# Altium schematic sheet pwm.SchDoc(pwm)
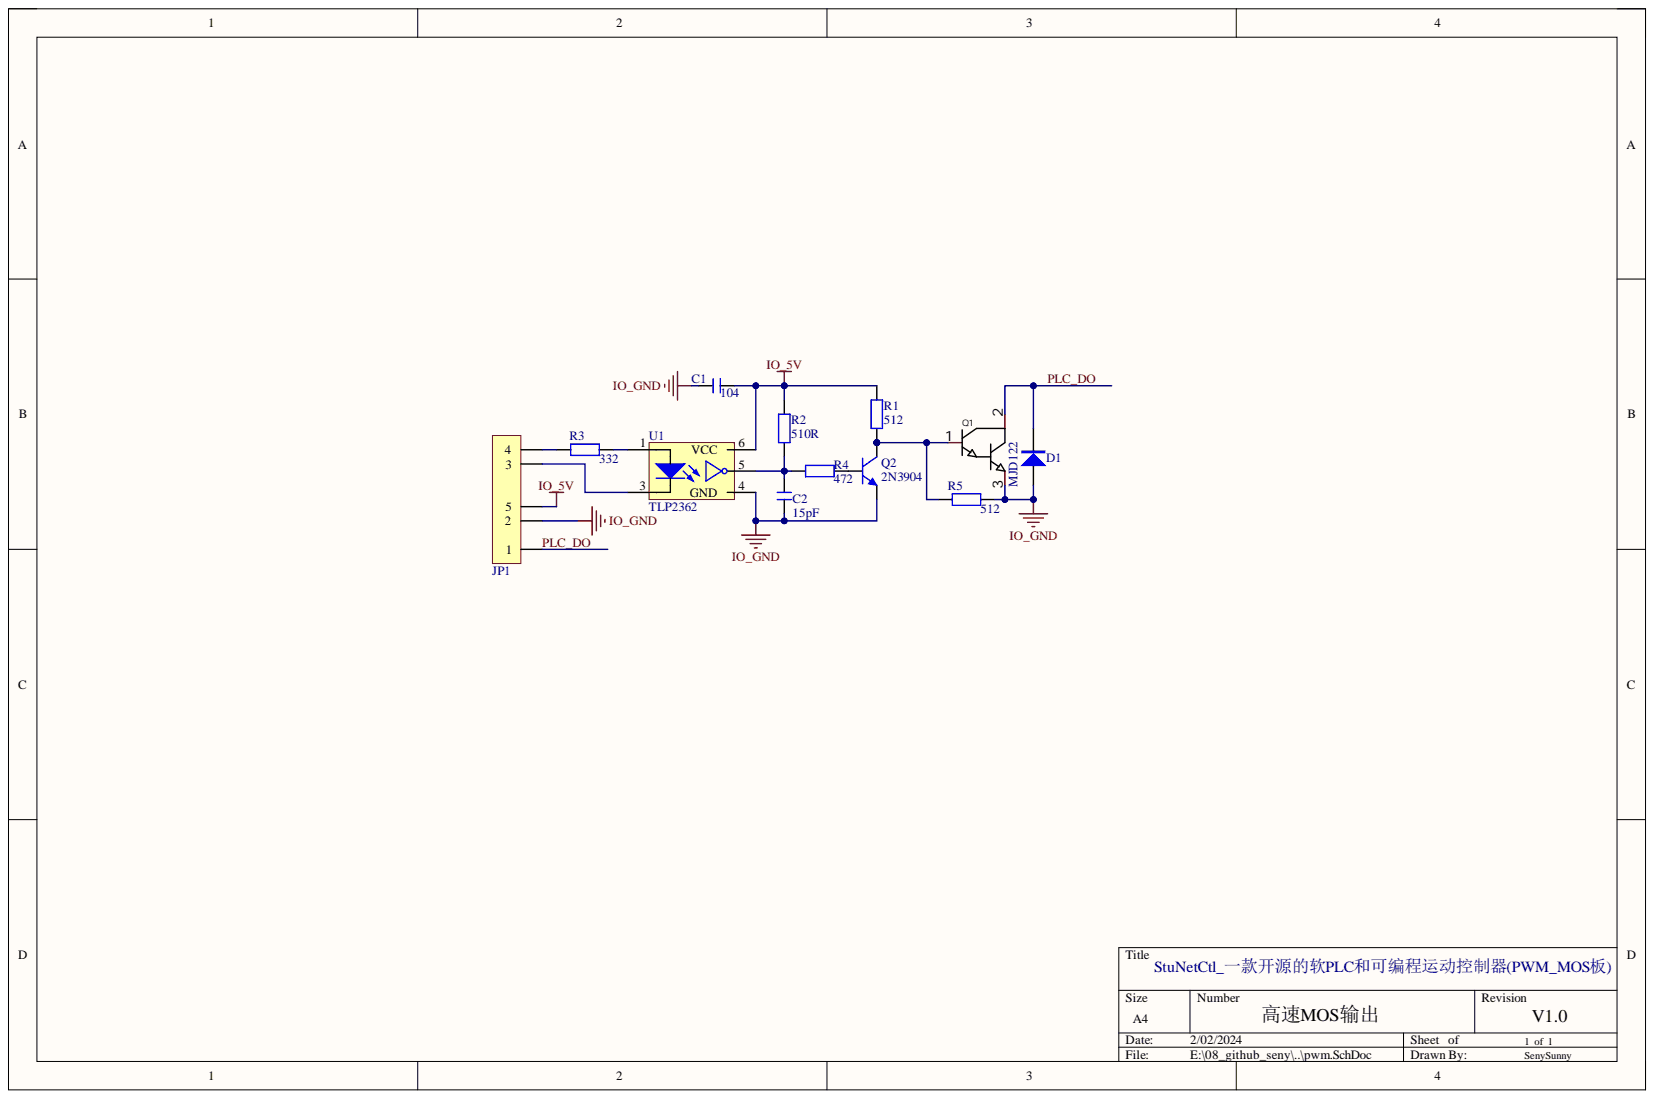
[diagram p0: pwm.SchDoc]

## Parts
C1  104  [C 0603]
C2  15pF  [C 0603]
D1  MJD122  [SMA(DO214AC)]
JP1  Header 5_DO  [HDR-1X5_H_do1]  (value not drawn)
Q1  MJD122  [TO252]
Q2  2N3904  [TO92A]
R1  512  [R 0603]
R2  510R  [R 0603]
R3  332  [R 0603]
R4  472  [R 0603]
R5  512  [R 0603]
U1  TLP2362  [SOP5]

## Nets
IO_5V: C1.2 JP1.5 R1.2 R2.2 U1.6(VCC)
IO_GND: C1.1 C2.1 D1.1 JP1.2 Q1.3 Q2.1 R5.2 U1.4(GND)
PLC_DO: D1.2 JP1.1 Q1.2
NetC2_2: C2.2 R2.1 R4.2 U1.5
NetJP1_3: JP1.3 U1.3
NetJP1_4: JP1.4 R3.1
NetQ1_1: Q1.1 Q2.3 R1.1 R5.1
NetQ2_2: Q2.2 R4.1
NetR3_2: R3.2 U1.1

## Library data of the parts
C1 C2 = C: 无极性贴片电容; 版权归属: 源创客; BOM名称: 无极性贴片电容; Component Kind: Standard; 学习资源: www.ooocke.com; Library Name: zblib_v1.IntLib
D1 = M7: 整流二极管; 版权归属: 源创客; BOM名称: 整流二极管; Component Kind: Standard; 学习资源: www.ooocke.com; Library Name: zblib_v1.IntLib
JP1 = Header 5_DO: 5P_DO模块接插件; BOM名称: 8P接插件; 版权归属: 源创客; Component Kind: Standard; 学习资源: www.ooocke.com; Library Name: zblib_v1.IntLib
Q1 = MJD122: BJT达林顿晶体管(NPN); Manufacturer: CJ(江苏长电/长晶); Add into BOM: yes; Device: MJD122_C59488; Component Kind: Standard; Convert to PCB: yes; Datasheet: https://atta.szlcsc.com/upload/public/pdf/source/20160918/1474166836957.pdf; JLCPCB Part Class: Extended Part; LCSC Part Name: MJD122; Manufacturer Part: MJD122; Name: MJD122; Supplier: LCSC; Supplier Footprint: TO-252-2(DPAK); Supplier Part: C59488; Symbol: MJD122; Library Name: zblib_v1.IntLib
Q2 = 8050-DIP: 高频放大-NPN型; 属性: 40V 1.5A 1W 100MHZ; 版权归属: 源创客; BOM名称: 高频放大-NPN型; Component Kind: Standard; 学习资源: www.ooocke.com; Library Name: zblib_v1.IntLib
R1-R5 = R: 贴片电阻; 版权归属: 源创客; BOM名称: 贴片电阻; Component Kind: Standard; 学习资源: www.ooocke.com; Library Name: zblib_v1.IntLib
U1 = TLP115: 超高速光耦; Component Kind: Standard; Library Name: zblib_v1.IntLib
